# Altium schematic sheet Sheet1.SchDoc
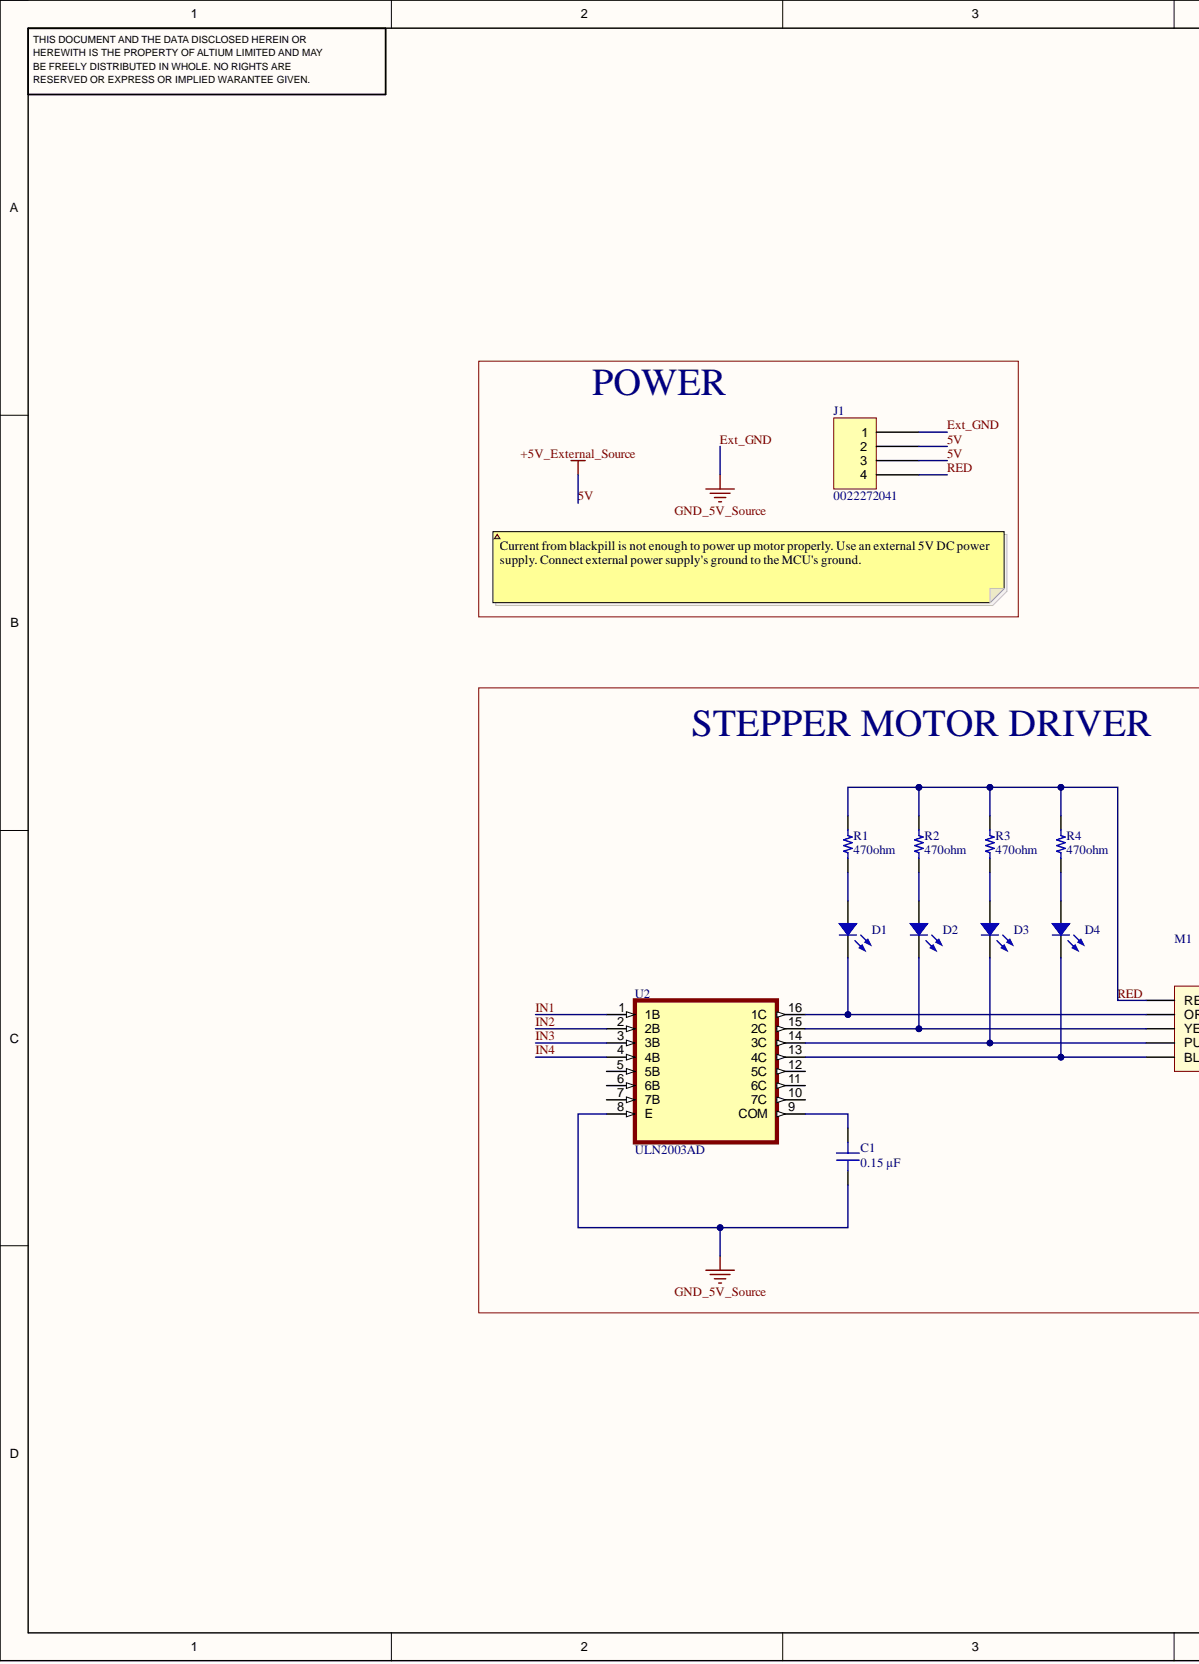
[diagram p0: Sheet1.SchDoc, left]
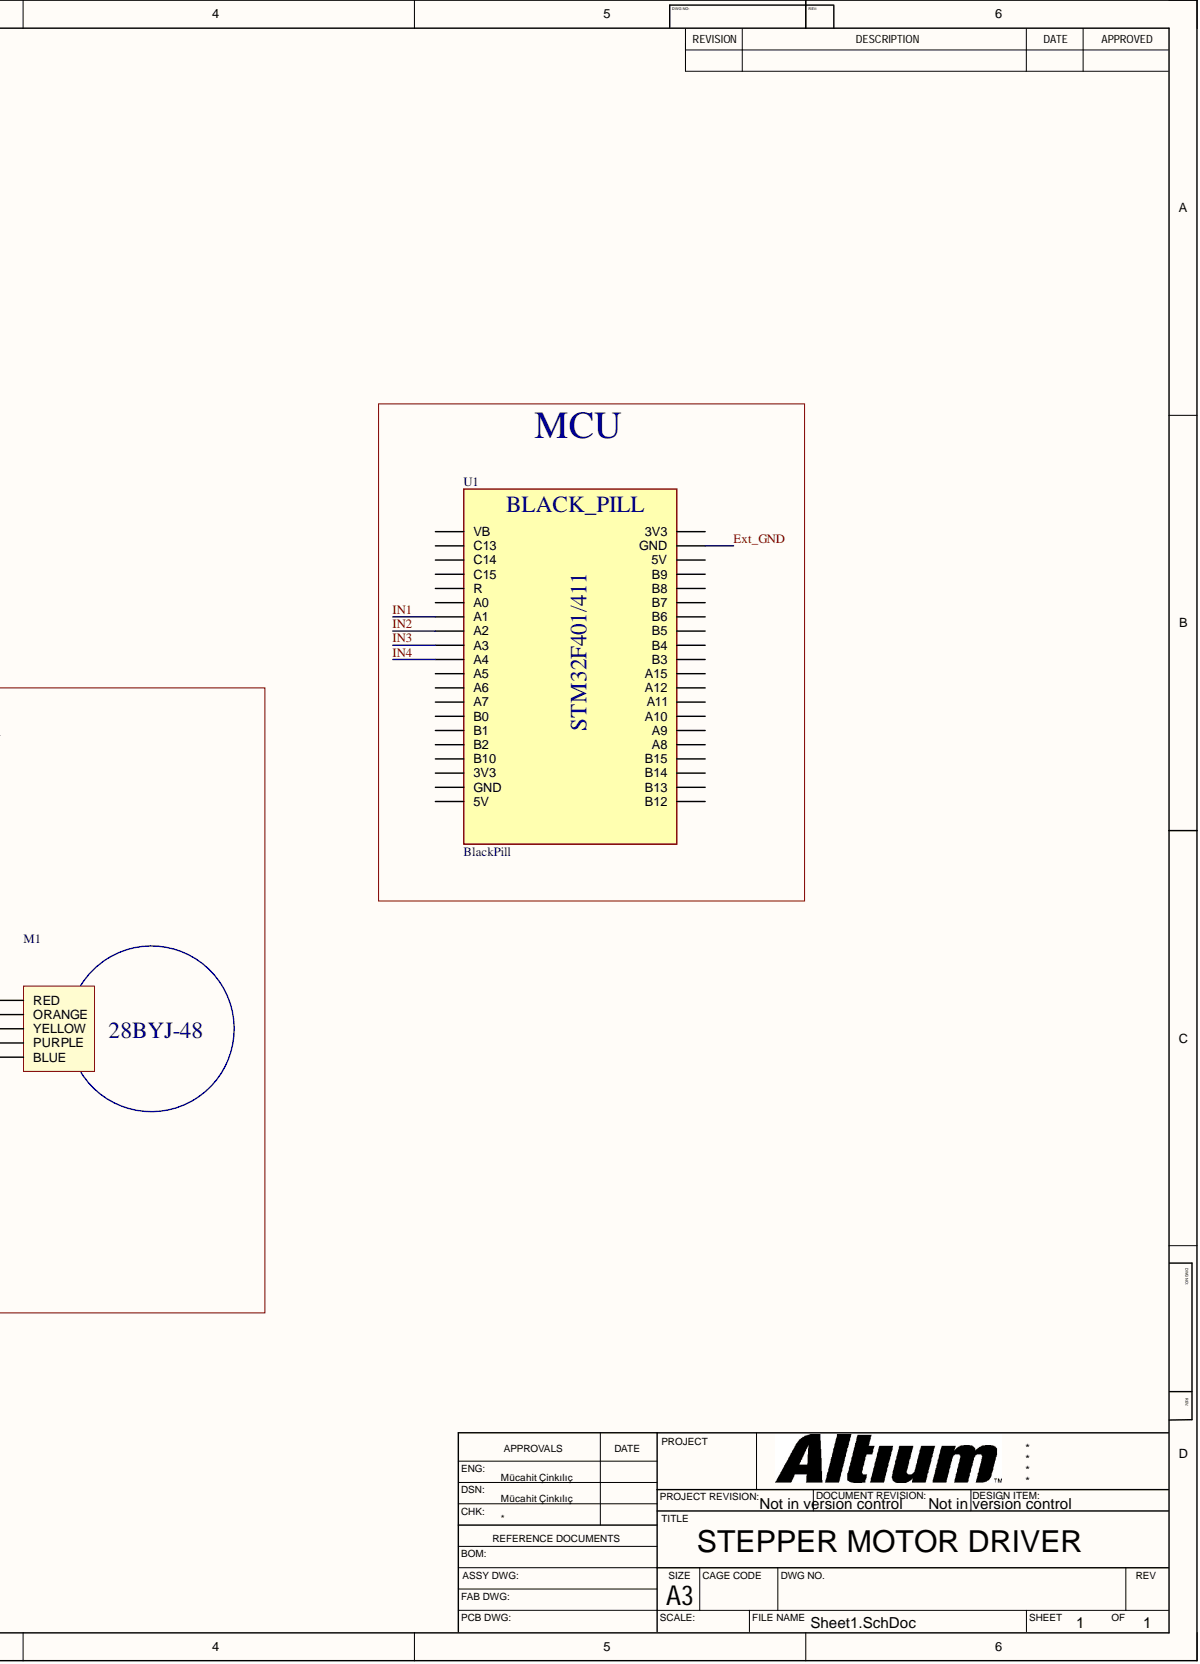
[diagram p1: Sheet1.SchDoc, right]

## Parts
C1  0.15 µF  [CAP FILM 0805]
D1  ASMT-QHBD-AFH0E  [Broadcom 4-PLLC 3228]  (value not drawn)
D2  ASMT-QHBD-AFH0E  [Broadcom 4-PLLC 3228]  (value not drawn)
D3  ASMT-QHBD-AFH0E  [Broadcom 4-PLLC 3228]  (value not drawn)
D4  ASMT-QHBD-AFH0E  [Broadcom 4-PLLC 3228]  (value not drawn)
J1  0022272041  [MOLEX KK 0022272041]
M1  28BYJ-48
R1  470ohm  [YAGEO RES 1206_3216]
R2  470ohm  [YAGEO RES 1206_3216]
R3  470ohm  [YAGEO RES 1206_3216]
R4  470ohm  [YAGEO RES 1206_3216]
U1  BlackPill
U2  ULN2003AD  [SOIC-16]

## Nets
5V: J1.2 J1.3
Ext_GND: C1.2 J1.1 U1.32(GND) U2.8(E)
IN1: U1.20(A1) U2.1(1B)
IN2: U1.21(A2) U2.2(2B)
IN3: U1.22(A3) U2.3(3B)
IN4: U1.23(A4) U2.4(4B)
RED: J1.4 M1.45(RED) R1.2 R2.2 R3.2 R4.2
NetC1_1: C1.1 U2.9(COM)
NetD1_1: D1.1 R1.1
NetD1_2: D1.2 M1.46(ORANGE) U2.16(1C)
NetD2_1: D2.1 R2.1
NetD2_2: D2.2 M1.49(YELLOW) U2.15(2C)
NetD3_1: D3.1 R3.1
NetD3_2: D3.2 M1.50(PURPLE) U2.14(3C)
NetD4_1: D4.1 R4.1
NetD4_2: D4.2 M1.8(BLUE) U2.13(4C)

Not connected: U1 1(B7) 7(C13) 8(GND) 9(5V) 13(B8) 14(C14) 15(C15) 16(R) 17(A0) 19(3V3) 26(B5) 27(B4) 28(B3) 29(B14) 30(B6) 33(B13) 34(B12) 41(A5) 42(A6) 43(A7) 44(B0) 45(B1) 46(B2) 48(5V) 49(B10) 50(3V3) 55(A15) 56(A12) 57(A11) 58(A10) 59(A9) 60(VB) 61(A8) 62(B15) 64(B9); U2 5(5B) 6(6B) 7(7B) 10(7C) 11(6C) 12(5C)

## Library data of the parts
C1 = 25MU154KZ22012: CAP FILM 0.15UF 10% 25VDC 0805; Manufacturer: Rubycon; Database Table Name: Capacitors - Film; Dielectric Material: Acrylic, Metallized; Voltage Rating - DC: 25V; Applications: DC Link, DC Filtering; Capacitance: 0.15 µF; Component Kind: Standard; ComponentLink1Description: Datasheet; ComponentLink1URL: http://www.rubycon.co.jp/en/catalog/e_pdfs/pmlcap/e_MU.pdf; ComponentLink2Description: DigiKey Link; ComponentLink2URL: https://www.digikey.com/en/products/detail/rubycon/25MU154KZ22012/9951731; Device Package: 0805 (2012 Metric); Height - Seated (Max): 0.047" (1.20mm); LastUpdated: 3.06.2024 20:14:31; Library Name: Celestial Altium Library - altium_library.DbLib; Lifecycle Status: Active; Minimum Order: 1; Mounting Type: Surface Mount; Operating Temperature: -55°C ~ 125°C; Package / Case: 0805 (2012 Metric); Packaging: TapeAndReel; Part Number: 25MU154KZ22012; Part Status: Active; Price: 1.08; Series: PMLCAP®, MU; Size / Dimension: 0.079" L x 0.049" W (2.00mm x 1.25mm); Supplier 1: DigiKey; Supplier Part Number 1: 1189-4329-1-ND; Termination: Solder Pads; Tolerance: ±10%; Value: 0.15 µF
D1-D4 = ASMT-QHBD-AFH0E: LED INDICATOR 0.5W RED/ORN 4PLCC; Manufacturer: Broadcom Limited; Color: Red; Current - Max: 300mA; Current - Test: 150mA; Database Table Name: LED - Lighting - Color; Flux @ Current/Temperature - Test: 24lm (15lm ~ 33lm); Lumens/Watt @ Current - Test: 64 lm/W; Temperature - Test: 25°C; Viewing Angle: 120°; Voltage - Forward (Vf) (Typ): 2.5V; Wavelength: 618nm (611nm ~ 625nm); Component Kind: Standard; ComponentLink1Description: Datasheet; ComponentLink1URL: https://docs.broadcom.com/docs/AV02-1841EN; ComponentLink2Description: DigiKey Link; ComponentLink2URL: http://digikey.com/product-detail/en/broadcom-limited/ASMT-QHBD-AFH0E/516-2951-1-ND/4240266; Device Package: 4-PLCC; Height - Seated (Max): 0.083" (2.10mm); LastUpdated: 24.04.2020 00:57:17; Library Name: Celestial Altium Library - altium_library.DbLib; Lifecycle Status: Active; Minimum Order: 1; Mounting Type: Surface Mount; Package / Case: 4-PLCC; Packaging: TapeAndReel; Part Number: ASMT-QHBD-AFH0E; Part Status: Active; Price: 0.42; Size / Dimension: 0.126" L x 0.110" W (3.20mm x 2.80mm); Supplier 1: DigiKey; Supplier Device Package: 4-PLCC; Supplier Part Number 1: 516-2951-1-ND
J1 = 0022272041: CONN HEADER VERT 4POS 2.54MM; Manufacturer: Molex; Contact Length - Mating: 0.295" (7.49mm); Contact Length - Post: 0.140" (3.56mm); Database Table Name: Connectors - Rectangular Headers Male Pins; Material Flammability Rating: UL94 V-0; Number of Positions: 4; Number of Positions Loaded: All; Overall Contact Length: 0.560" (14.22mm); Pitch - Mating: 0.100" (2.54mm); Shrouding: Shrouded - 1 Wall; Style: Board to Cable/Wire; Component Kind: Standard; ComponentLink1Description: Datasheet; ComponentLink1URL: https://www.molex.com/pdm_docs/sd/022272041_sd.pdf; ComponentLink2Description: DigiKey Link; ComponentLink2URL: http://digikey.com/product-detail/en/molex/0022272041/WM4113-ND/1130579; Connector Type: Header; Contact Finish - Mating: Tin; Contact Finish - Post: Tin; Contact Finish Thickness - Mating: 100.0µin (2.54µm); Contact Material: Brass; Contact Shape: Square; Contact Type: Male Pin; Fastening Type: Friction Lock; LastUpdated: 12.01.2020 23:52:05; Library Name: Celestial Altium Library - altium_library.DbLib; Lifecycle Status: Active; Minimum Order: 1; Mounting Type: Through Hole; Number of Rows: 1; Packaging: Bulk; Part Number: 0022272041; Part Status: Active; PartId: 78; Price: 0.40; Series: KK 6410; Supplier 1: DigiKey; Supplier Part Number 1: WM4113-ND; Termination: Solder; Insulation Color: Natural; Insulation Height: 0.461" (11.70mm); Insulation Material: Polyamide (PA), Nylon
R1-R4 = RL1206FR-7W0R1L: RES 0.1 OHM 1% 1/2W 1206; Manufacturer: Yageo; Database Table Name: Resistors - Surface Mount - 1206; Power (Watts): 0.5W, 1/2W; Resistance: 100 mOhms; Temperature Coefficient: ±600ppm/°C; Value: 100 mOhms; Component Kind: Standard; ComponentLink1Description: Datasheet; ComponentLink1URL: http://www.yageo.com/documents/recent/PYu-RL_Group_521_RoHS_L_2.pdf; ComponentLink2Description: DigiKey Link; ComponentLink2URL: https://www.digikey.com/en/products/detail/yageo/RL1206FR-7W0R1L/2827682; Composition: Thick Film; Device Package: 1206 (3216 Metric); Features: Current Sense; Height - Seated (Max): 0.026" (0.65mm); LastUpdated: 25.07.2024 09:21:39; Library Name: Celestial Altium Library - altium_library.DbLib; Lifecycle Status: Active; Minimum Order: 1; Number of Terminations: 2; Operating Temperature: -55°C ~ 125°C; Package / Case: 1206 (3216 Metric); Packaging: TapeAndReel; Part Number: RL1206FR-7W0R1L; Part Status: Active; PartId: 26837; Price: 0.08; Ratings: AEC-Q200; Series: RL; Size / Dimension: 0.122" L x 0.063" W (3.10mm x 1.60mm); Supplier 1: DigiKey; Supplier Device Package: 1206; Supplier Part Number 1: 311-0.1LYCT-ND; Tolerance: ±1%
U2 = ULN2003AD: 50-V, 7-ch darlington transistor array, -20C to 70C; MF: Texas Instruments; Availability: In Stock; Check_prices: https://www.snapeda.com/parts/ULN2003AD/Texas+Instruments/view-part/?ref=eda; Component Kind: Standard; Library Name: MyAltLib.SchLib; MP: ULN2003AD; Package: SOIC-16 Texas Instruments; Price: None; Purchase-URL: https://pricing.snapeda.com/search/part/ULN2003AD/?ref=eda; SnapEDA_Link: https://www.snapeda.com/parts/ULN2003AD/Texas+Instruments/view-part/?ref=snap
M1 = 28BYJ-48: Component Kind: Standard; Library Name: MyAltLib.SchLib
U1 = BlackPill: Component Kind: Standard; Library Name: MyAltLib.SchLib
